# Altium schematic sheet C-Connector.SchDoc(C-Connector)
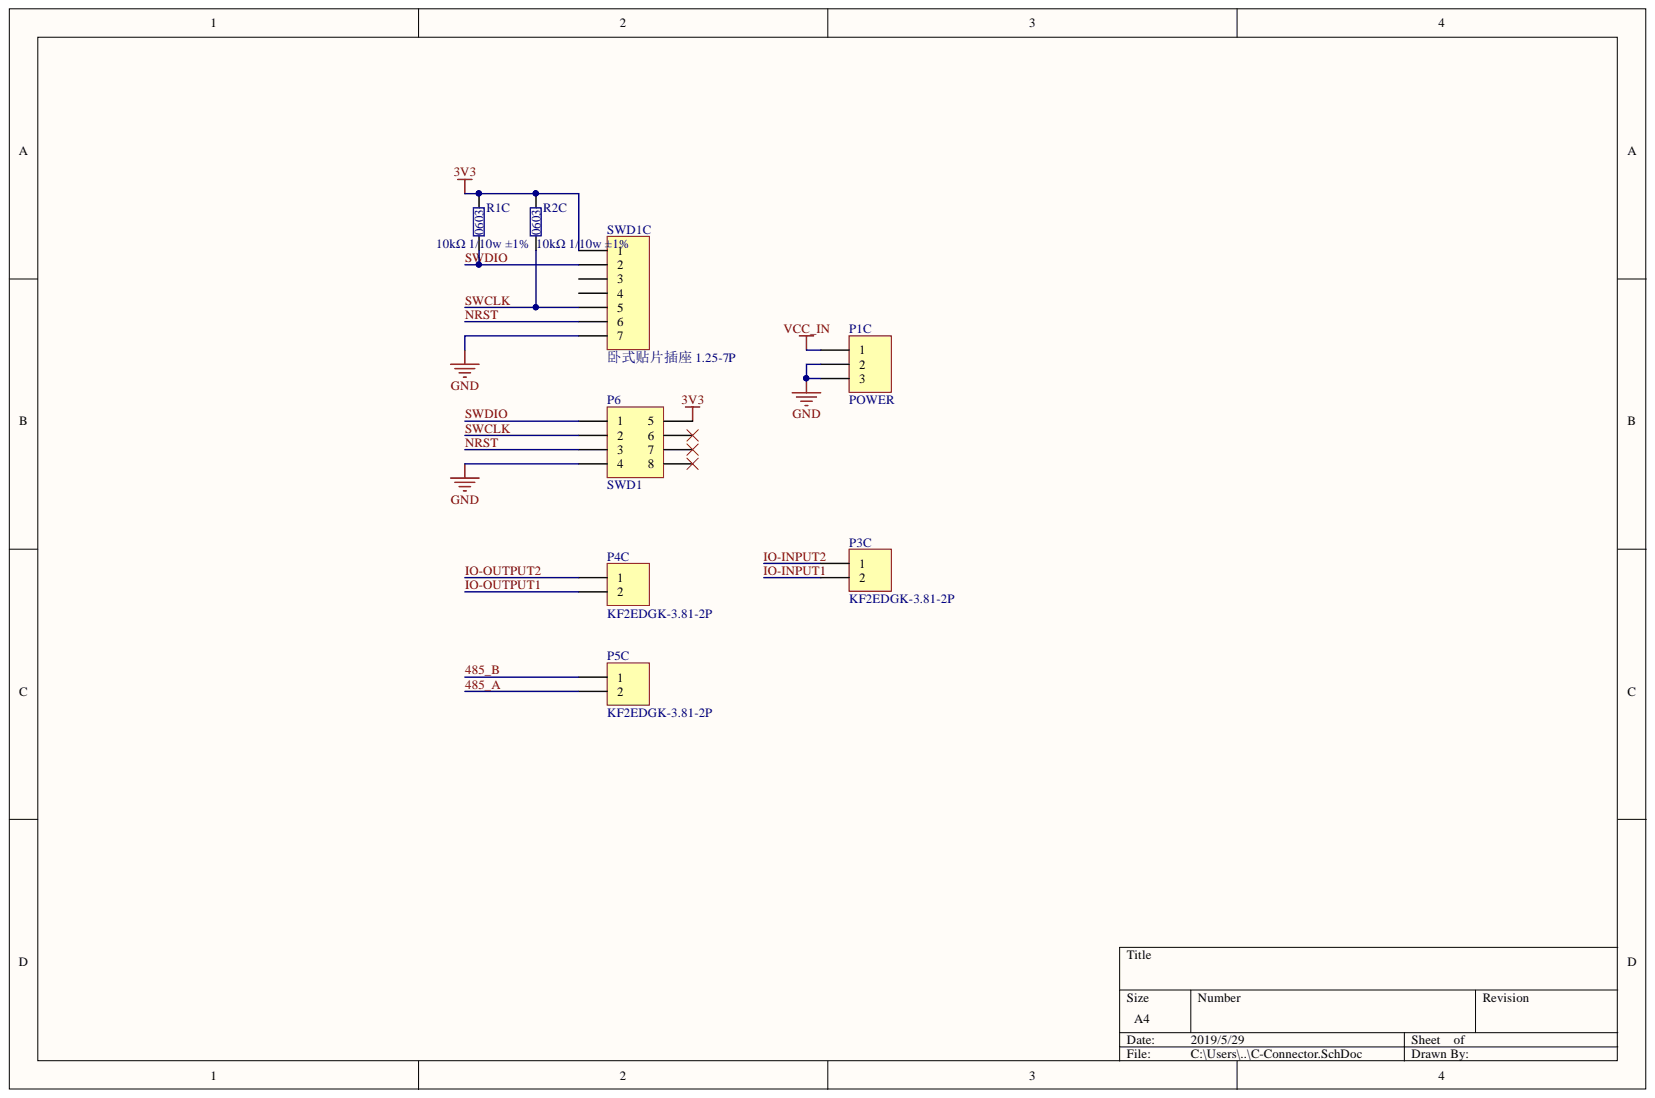
[diagram p0: C-Connector.SchDoc]

## Parts
P1C  POWER  [DC-0005]
P3C  KF2EDGK-3.81-2P  [KF2EDG3.81-2P]
P4C  KF2EDGK-3.81-2P  [KF2EDG3.81-2P]
P5C  KF2EDGK-3.81-2P  [KF2EDG3.81-2P]
P6  SWD1  [TESTPOINT]
R1C  10kΩ 1/10w ±1%  [R-0603]
R2C  10kΩ 1/10w ±1%  [R-0603]
SWD1C  卧式贴片插座 1.25-7P  [插座-贴片卧式-7P]

## Nets
3V3: P6.5 R1C.2 R2C.2 SWD1C.1
GND: P1C.2 P1C.3 P6.4 SWD1C.7
VCC_IN: P1C.1
485_A: P5C.2
485_B: P5C.1
IO-INPUT1: P3C.2
IO-INPUT2: P3C.1
IO-OUTPUT1: P4C.2
IO-OUTPUT2: P4C.1
NRST: P6.3 SWD1C.6
SWCLK: P6.2 R2C.1 SWD1C.5
SWDIO: P6.1 R1C.1 SWD1C.2

Not connected: P6 6 7 8; SWD1C 3 4

## Library data of the parts
P1C = Header 3: Header, 3-Pin; Component Kind: Standard; LatestRevisionDate: 17-Jul-2002; LatestRevisionNote: Re-released for DXP Platform.; Library Name: Miscellaneous Connectors.IntLib; Signal Integrity: Connector; Publisher: Altium Limited
P3C P4C P5C = Header 2: Header, 2-Pin; Component Kind: Standard; LatestRevisionDate: 17-Jul-2002; LatestRevisionNote: Re-released for DXP Platform.; Library Name: Miscellaneous Connectors.IntLib; Publisher: Altium Limited
  P3C P5C: Signal Integrity: Connector
P6 = Header 4X2A: Header, 4-Pin, Dual row; Component Kind: Standard; LatestRevisionDate: 17-Jul-2002; LatestRevisionNote: Re-released for DXP Platform.; Library Name: Miscellaneous Connectors.IntLib; Signal Integrity: Connector; Publisher: Altium Limited
R1C R2C = 2kΩ 1/10w ±1%: Component Kind: Standard; Library Name: dahuan.SchLib
SWD1C = MHDR1X7: Header, 7-Pin; Component Kind: Standard; LatestRevisionDate: 17-Jul-2002; LatestRevisionNote: Re-released for DXP Platform.; Library Name: Miscellaneous Connectors.IntLib; Publisher: Altium Limited
